# Altium schematic sheet MCU_&_Sensors.SchDoc
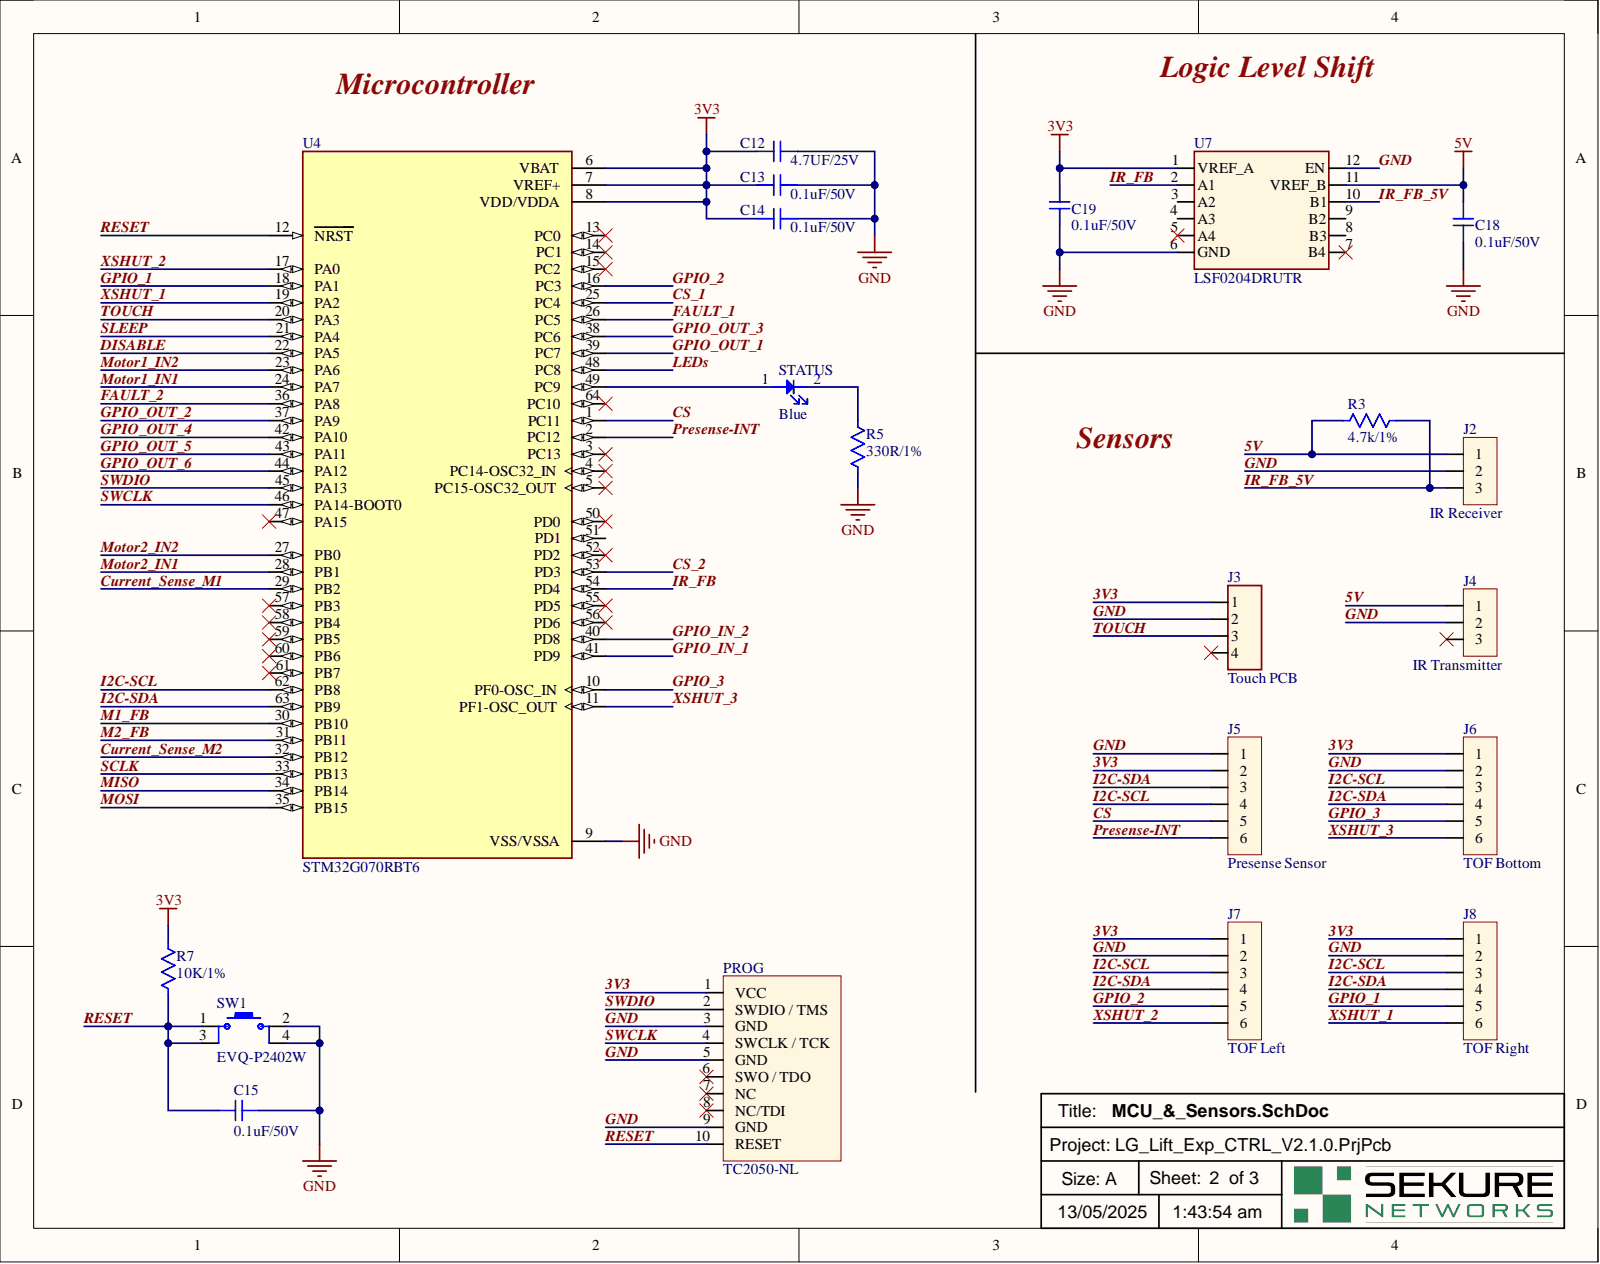
[diagram p0: MCU_&_Sensors.SchDoc]

## Parts
C12  value 4.7UF/25V  [CAP 0603]  (hidden comment: Capacitor)
C13  value 0.1uF/50V  [CAP 0603]  (hidden comment: Ceramic Capacitor)
C14  value 0.1uF/50V  [CAP 0603]  (hidden comment: Ceramic Capacitor)
C15  value 0.1uF/50V  [CAP 0603]  (hidden comment: Ceramic Capacitor)
C18  value 0.1uF/50V  [CAP 0603]  (hidden comment: Ceramic Capacitor)
C19  value 0.1uF/50V  [CAP 0603]  (hidden comment: Ceramic Capacitor)
J2  value IR Receiver  [S3B-PH_JST]  (hidden comment: Connector)
J3  value Touch PCB  [SM04B-PASS-TBT]  (hidden comment: Connector)
J4  value IR Transmitter  [S3B-PH_JST]  (hidden comment: Connector)
J5  value Presense Sensor  [S6B-PH_JST]  (hidden comment: Connector)
J6  value TOF Bottom  [S6B-PH_JST]  (hidden comment: Connector)
J7  value TOF Left  [S6B-PH_JST]  (hidden comment: Connector)
J8  value TOF Right  [S6B-PH_JST]  (hidden comment: Connector)
PROG  value TC2050-NL  [TC2050_full]  (hidden comment: Programming Connector)
R3  value 4.7k/1%  [RES 0603]  (hidden comment: Resistor)
R5  value 330R/1%  [RES 0603]  (hidden comment: Resistor)
R7  value 10K/1%  [RES 0603]  (hidden comment: Resistor)
STATUS  value Blue  Color: Blue  [APT1608SURCK]  (hidden comment: LED)
SW1  value EVQ-P2402W  [EVQ-P2402W]  (hidden comment: Switch)
U4  Microcontroller  value STM32G070RBT6  [64-LQFP]
U7  value LSF0204DRUTR  [LSF0204RUTR]  (hidden comment: IC)

## Nets
3V3: C12.2 C13.2 C14.2 C19.1 J3.1 J5.2 J6.1 J7.1 J8.1 PROG.1(VCC) R7.1 U4.6(VBAT) U4.7(VREF+) U4.8(VDD/VDDA) U7.1(VREF_A)
5V: C18.1 J2.1 J4.1 R3.2 U7.11(VREF_B)
CS: J5.5 U4.1(PC11)
CS_1: U4.25(PC4)
CS_2: U4.53(PD3)
Current_Sense_M1: U4.29(PB2)
Current_Sense_M2: U4.32(PB12)
DISABLE: U4.22(PA5)
FAULT_1: U4.26(PC5)
FAULT_2: U4.36(PA8)
GND: C12.1 C13.1 C14.1 C15.1 C18.2 C19.2 J2.2 J3.2 J4.2 J5.1 J6.2 J7.2 J8.2 PROG.3(GND) PROG.5(GND) PROG.9(GND) R5.1 SW1.2 SW1.4 U4.9(VSS/VSSA) U7.6(GND) U7.12(EN)
GPIO_1: J8.5 U4.18(PA1)
GPIO_2: J7.5 U4.16(PC3)
GPIO_3: J6.5 U4.10(PF0-OSC_IN)
GPIO_IN_1: U4.41(PD9)
GPIO_IN_2: U4.40(PD8)
GPIO_OUT_1: U4.39(PC7)
GPIO_OUT_2: U4.37(PA9)
GPIO_OUT_3: U4.38(PC6)
GPIO_OUT_4: U4.42(PA10)
GPIO_OUT_5: U4.43(PA11)
GPIO_OUT_6: U4.44(PA12)
I2C-SCL: J5.4 J6.3 J7.3 J8.3 U4.62(PB8)
I2C-SDA: J5.3 J6.4 J7.4 J8.4 U4.63(PB9)
IR_FB: U4.54(PD4) U7.2(A1)
IR_FB_5V: J2.3 R3.1 U7.10(B1)
LEDs: U4.48(PC8)
M1_FB: U4.30(PB10)
M2_FB: U4.31(PB11)
MISO: U4.34(PB14)
MOSI: U4.35(PB15)
Motor1_IN1: U4.24(PA7)
Motor1_IN2: U4.23(PA6)
Motor2_IN1: U4.28(PB1)
Motor2_IN2: U4.27(PB0)
Presense-INT: J5.6 U4.2(PC12)
RESET: C15.2 PROG.10(RESET) R7.2 SW1.1 SW1.3 U4.12(NRST)
SCLK: U4.33(PB13)
SLEEP: U4.21(PA4)
SWCLK: PROG.4(SWCLK_/_TCK) U4.46(PA14-BOOT0)
SWDIO: PROG.2(SWDIO_/_TMS) U4.45(PA13)
TOUCH: J3.3 U4.20(PA3)
XSHUT_1: J8.6 U4.19(PA2)
XSHUT_2: J7.6 U4.17(PA0)
XSHUT_3: J6.6 U4.11(PF1-OSC_OUT)
NetR5_2: R5.2 STATUS.2
NetSTATUS_1: STATUS.1 U4.49(PC9)

Not connected: J3 4; J4 3; PROG 6(SWO_/_TDO) 7(NC) 8(NC/TDI); U4 3(PC13) 4(PC14-OSC32_IN) 5(PC15-OSC32_OUT) 13(PC0) 14(PC1) 15(PC2) 47(PA15) 50(PD0) 51(PD1) 52(PD2) 55(PD5) 56(PD6) 57(PB3) 58(PB4) 59(PB5) 60(PB6) 61(PB7) 64(PC10); U7 3(A2) 4(A3) 5(A4) 7(B4) 8(B3) 9(B2)

## Library data of the parts
C12 = GRM188R61E475KE11D: CAP CER 4.7UF 25V X5R 0603; Manufacturer: Murata; Material: Ceramic; Tolerance: 10%; Capacitance: 4.7uF; Case Code (Imperial): 0603; Case Code (Metric): 1608; Case/Package: 0603; Component Kind: Standard; ComponentLink1Description: https://datasheet.ciiva.com/46444/1769893-46444982.pdf?src-supplier=Element14; ComponentLink1URL: https://datasheet.ciiva.com/46444/1769893-46444982.pdf?src-supplier=Element14; ComponentLink2Description: https://datasheet.ciiva.com/46444/1769893-46444983.pdf?src-supplier=Element14; ComponentLink2URL: https://datasheet.ciiva.com/46444/1769893-46444983.pdf?src-supplier=Element14; ComponentLink3Description: https://datasheet.ciiva.com/14891/partnumbering-e-01-14891102.pdf?src-supplier=Digi-Key; ComponentLink3URL: https://datasheet.ciiva.com/14891/partnumbering-e-01-14891102.pdf?src-supplier=Digi-Key; ComponentLink4Description: https://datasheet.ciiva.com/14891/partnumbering-e-01-14891103.pdf?src-supplier=Digi-Key; ComponentLink4URL: https://datasheet.ciiva.com/14891/partnumbering-e-01-14891103.pdf?src-supplier=Digi-Key; Depth: 0.8mm; Dielectric: X5R; DigiKey: 490-7203-1-ND; Height: 0.8mm; Lead Free: Lead Free; Length: 1.6mm; Library Name: Ceramic Capacitors.IntLib; Max Operating Temperature: 85°C; Min Operating Temperature: -55°C; Mount: Surface Mount; P/N: GRM188R61E475KE11D; Package Quantity: 4000; Packaging: Cut Tape; Pricing 1: 1=0.41000, 10=0.28400, 100=0.17040, 500=0.12780, 1000=0.10793 (USD); REACH SVHC: No SVHC; RoHS: Yes; Stock 1: 593246; Supplier 1: Digi-Key; Thickness: 0.031inch; Voltage: 25V; Voltage Rating: 25V; Voltage Rating (DC): 25V; Width: 0.031inch
C13-C15 C18 C19 = C0603G104K5RAC7867: CAP CER 0.1UF 50V X7R 0603; Manufacturer: KEMET; Capacitance: 100nF; Tolerance: 10%; Case Code (Imperial): 0603; Case Code (Metric): 1608; Case/Package: 0603; Component Kind: Standard; ComponentLink1Description: http://www.kemet.com/Lists/ProductCatalog/Attachments/44/KEM_CC106_HITEMP.pdf?src-supplier=Digi-Key; ComponentLink1URL: http://www.kemet.com/Lists/ProductCatalog/Attachments/44/KEM_CC106_HITEMP.pdf?src-supplier=Digi-Key; DigiKey: 399-11919-1-ND; Features: High Temperature; Length: 0.063inch; Library Name: Ceramic Capacitors.IntLib; Max Operating Temperature: 175°C; Min Operating Temperature: -55°C; Mount: Surface Mount; P/N: C0603G104K5RAC7867; Packaging: Cut Tape; Pricing 1: 1=2.02000, 10=1.63200, 50=1.42440, 100=1.24640, 500=0.94962, 1000=0.83092 (USD); RoHS: Yes; Stock 1: 15997; Supplier 1: Digi-Key; Thickness: 0.034inch; Voltage Rating: 50V; Width: 0.031inch
J2 J4 = S3B-PH-SM4-TB: Header S3B-PH-SM4-TB, 2.00mm, 3-contacts, side entry, SMT, /1000/reel; Manufacturer: JST; Component Kind: Standard; DigiKey: 455-S3B-PH-SM4-TBCT-ND; Library Name: Connectors.IntLib; Orientation: Horizontal; P/N: S3B-PH-SM4-TB; Pricing: 1=0.68, 10=0.591, 100=0.4869, 500=0.42336 (USD); RoHS: No; Stock: 8368; Supplier: Digikey
J3 = SM04B-PASS-TBT: CONN HEADER SMD R/A 4POS 2MM; Manufacturer: JST; Category: LDO; Component Kind: Standard; DigiKey: 455-2645-1-ND; Library Name: Connectors.IntLib; P/N: SM04B-PASS-TBT; Pricing: 1=1.14, 10=0.966, 100=0.8674, 500=0.70972 (USD); RoHS: No; Stock: 6960; Supplier: Digikey
J5-J8 = S6B-PH-SM4-TB: Header S6B-PH-SM4-TB, 2.00mm, 6-contacts, side entry, SMT, /1000/reel; Manufacturer: JST; Component Kind: Standard; DigiKey: 455-S6B-PH-SM4-TBCT-ND; Library Name: Connectors.IntLib; P/N: S6B-PH-SM4-TB; Pricing: 1=0.89, 10=0.748, 100=0.6716, 500=0.54948 (USD); RoHS: No; Stock: 10902; Supplier: Digikey
PROG = TC2050_Full: “No Legs” 10-pin Plug-of-Nails™ Cable fitted with a 10-pin 0.1″ pitch ribbon connector.; Assembly: DNP; Component Kind: Standard; Library Name: Programming Connectors.IntLib
R3 = ERJ-3EKF4701V: RES SMD, 4.7K 0603, 0.1W, 1%; Manufacturer: Panasonic; Max Power Dissipation: 100mW; Resistance: 4.7kR; Temperature Coefficient: 100ppm/°C; Voltage Rating: 75V; Case Code (Imperial): 0603; Case Code (Metric): 1608; Case/Package: 0603; Component Kind: Standard; ComponentLink1Description: https://datasheet.ciiva.com/16449/aoa0000ce2-16449479.pdf?src-supplier=Future+Electronics; ComponentLink1URL: https://datasheet.ciiva.com/16449/aoa0000ce2-16449479.pdf?src-supplier=Future+Electronics; ComponentLink2Description: https://datasheet.ciiva.com/16511/aoa0000ce2-16511783.pdf?src-supplier=Avnet+Asia; ComponentLink2URL: https://datasheet.ciiva.com/16511/aoa0000ce2-16511783.pdf?src-supplier=Avnet+Asia; ComponentLink3Description: https://datasheet.ciiva.com/9195/aoa0000ce2-9195740.pdf?src-supplier=Avnet; ComponentLink3URL: https://datasheet.ciiva.com/9195/aoa0000ce2-9195740.pdf?src-supplier=Avnet; ComponentLink4Description: https://datasheet.ciiva.com/16758/70260748-16758189.pdf?src-supplier=Allied+Electronics; ComponentLink4URL: https://datasheet.ciiva.com/16758/70260748-16758189.pdf?src-supplier=Allied+Electronics; ComponentLink5Description: https://datasheet.ciiva.com/24160/aoa0000ce2-24160445.pdf?src-supplier=Mouser; ComponentLink5URL: https://datasheet.ciiva.com/24160/aoa0000ce2-24160445.pdf?src-supplier=Mouser; ComponentLink6Description: https://datasheet.ciiva.com/46372/1678782-46372717.pdf?src-supplier=Element14; ComponentLink6URL: https://datasheet.ciiva.com/46372/1678782-46372717.pdf?src-supplier=Element14; ComponentLink7Description: https://datasheet.ciiva.com/17213/aoa0000ce2-17213182.pdf?src-supplier=Avnet+Europe; ComponentLink7URL: https://datasheet.ciiva.com/17213/aoa0000ce2-17213182.pdf?src-supplier=Avnet+Europe; ComponentLink8Description: https://datasheet.ciiva.com/7830/426256-7830985.pdf?src-supplier=Element14; ComponentLink8URL: https://datasheet.ciiva.com/7830/426256-7830985.pdf?src-supplier=Element14; ComponentLink9Description: https://datasheet.ciiva.com/46372/1678782-46372716.pdf?src-supplier=Element14; ComponentLink9URL: https://datasheet.ciiva.com/46372/1678782-46372716.pdf?src-supplier=Element14; ComponentLink10Description: https://datasheet.ciiva.com/7830/aoa0000ce2-7830735.pdf?src-supplier=Element14; ComponentLink10URL: https://datasheet.ciiva.com/7830/aoa0000ce2-7830735.pdf?src-supplier=Element14; Composition: Thick Film; Contact Plating: Tin; Depth: 0.8mm; DigiKey: P4.70KHDKR-ND; Features: Automotive AEC-Q200; Height: 0.022inch; Lead Free: Lead Free; Length: 1.6mm; Library Name: Resistors.IntLib; Max Operating Temperature: 155°C; Military Standard: Not; Min Operating Temperature: -55°C; Mount: Surface Mount; Number of Pins: 2; Number of Terminations: 2; P/N: ERJ-3EKF4701V; Package Quantity: 1; Packaging: Tape and Reel; Power Rating: 100mW; Pricing 1: 1=0.10000, 10=0.05700, 100=0.02340, 1000=0.01049, 2500=0.00911 (USD); Radiation Hardening: No; REACH SVHC: No SVHC; RoHS: Yes; Stock 1: 588466; Supplier 1: Digi-Key; Termination: SMD/SMT; Tolerance: 1%; Voltage Rating (DC): 75V; Weight: 7.1E-05oz; Width: 0.031inch
R5 = ERJ3EKF3300V: RES SMD 330 OHM 1% 1/10W 0603; Manufacturer: Panasonic; Max Power Dissipation: 100mW; Resistance: 330R; Temperature Coefficient: 100ppm/°C; Voltage Rating: 75V; Case Code (Imperial): 0603; Case Code (Metric): 1608; Case/Package: 0603; Component Kind: Standard; ComponentLink1Description: https://datasheet.ciiva.com/48531/aoa0000ce2-48531001.pdf?src-supplier=Avnet; ComponentLink1URL: https://datasheet.ciiva.com/48531/aoa0000ce2-48531001.pdf?src-supplier=Avnet; ComponentLink2Description: https://datasheet.ciiva.com/22721/426256-22721099.pdf?src-supplier=Element14; ComponentLink2URL: https://datasheet.ciiva.com/22721/426256-22721099.pdf?src-supplier=Element14; ComponentLink3Description: https://datasheet.ciiva.com/46373/1678782-46373899.pdf?src-supplier=Element14; ComponentLink3URL: https://datasheet.ciiva.com/46373/1678782-46373899.pdf?src-supplier=Element14; ComponentLink4Description: https://datasheet.ciiva.com/7886/aoa0000ce2-7886729.pdf?src-supplier=Element14; ComponentLink4URL: https://datasheet.ciiva.com/7886/aoa0000ce2-7886729.pdf?src-supplier=Element14; ComponentLink5Description: https://datasheet.ciiva.com/9117/aoa0000ce2-9117132.pdf?src-supplier=Avnet; ComponentLink5URL: https://datasheet.ciiva.com/9117/aoa0000ce2-9117132.pdf?src-supplier=Avnet; ComponentLink6Description: https://datasheet.ciiva.com/46373/1678782-46373900.pdf?src-supplier=Element14; ComponentLink6URL: https://datasheet.ciiva.com/46373/1678782-46373900.pdf?src-supplier=Element14; Composition: Thick Film; Contact Plating: Tin; Depth: 0.8mm; DigiKey: P330HCT-ND; Features: Automotive AEC-Q200; Height: 0.45mm; Lead Free: Lead Free; Length: 1.6mm; Library Name: Resistors.IntLib; Max Operating Temperature: 155°C; Military Standard: Not; Min Operating Temperature: -55°C; Mount: Surface Mount; Number of Pins: 2; Number of Terminations: 2; P/N: ERJ3EKF3300V; Package Quantity: 5000; Packaging: Tape and Reel; Power Rating: 100mW; Pricing 1: 1=0.10000, 10=0.07000, 100=0.02840, 1000=0.01275, 2500=0.01106 (USD); Radiation Hardening: No; REACH SVHC: No SVHC; RoHS: Yes; Stock 1: 1950276; Supplier 1: Digi-Key; Termination: SMD/SMT; Tolerance: 1%; Voltage Rating (DC): 75V; Weight: 7.1E-05oz; Width: 0.8mm
R7 = RC0603FR-0710KL: RES SMD 10K OHM 1% 1/10W 0603; Manufacturer: Yageo; Power Rating: 100mW; Resistance: 10kR; Temperature Coefficient: 100ppm/°C; Voltage Rating: 75V; Voltage Rating (DC): 50V; Case Code (Imperial): 0603; Case Code (Metric): 1608; Case/Package: 0603; Component Kind: Standard; ComponentLink1Description: https://datasheet.ciiva.com/7660/38590-7660789.pdf?src-supplier=Element14; ComponentLink1URL: https://datasheet.ciiva.com/7660/38590-7660789.pdf?src-supplier=Element14; ComponentLink2Description: https://datasheet.ciiva.com/15189/getdatasheetpartid-409289-15189591.pdf?src-supplier=Verical; ComponentLink2URL: https://datasheet.ciiva.com/15189/getdatasheetpartid-409289-15189591.pdf?src-supplier=Verical; ComponentLink3Description: https://datasheet.ciiva.com/46440/1868787-46440891.pdf?src-supplier=Element14; ComponentLink3URL: https://datasheet.ciiva.com/46440/1868787-46440891.pdf?src-supplier=Element14; ComponentLink4Description: https://datasheet.ciiva.com/7983/443971-7983596.pdf?src-supplier=Element14; ComponentLink4URL: https://datasheet.ciiva.com/7983/443971-7983596.pdf?src-supplier=Element14; ComponentLink5Description: https://datasheet.ciiva.com/7746/1738695-7746239.pdf?src-supplier=Element14; ComponentLink5URL: https://datasheet.ciiva.com/7746/1738695-7746239.pdf?src-supplier=Element14; ComponentLink6Description: https://datasheet.ciiva.com/22747/443971-22747519.pdf?src-supplier=Element14; ComponentLink6URL: https://datasheet.ciiva.com/22747/443971-22747519.pdf?src-supplier=Element14; Composition: Thick Film; Depth: 0.8mm; DigiKey: 311-10.0KHRDKR-ND; Height: 0.45mm; Lead Free: Lead Free; Lead Pitch: 0.3mm; Length: 1.6mm; Library Name: Resistors.IntLib; Max Operating Temperature: 155°C; Military Standard: Not; Min Operating Temperature: -55°C; Number of Pins: 2; P/N: RC0603FR-0710KL; Packaging: Tape and Reel; Pricing 1: 1=0.10000, 10=0.02300, 100=0.00940, 1000=0.00421, 2500=0.00366 (USD); REACH SVHC: No SVHC; RoHS: Yes; Stock 1: 55833360; Supplier 1: Digi-Key; Termination: SMD/SMT; Tolerance: 1%; Weight: 7.1E-05oz; Width: 0.8mm
STATUS = APT1608QBC/D: Single Color LED, Blue, Water Clear, 1.2mm; Manufacturer: Kingbright; Channels: 1; Forward Current: 30mA; Luminous Intensity-Nom: 100mcd; Overall Height: 0.75mm; Shape: Rectangular; Viewing Angle: 120°; Category: LEDs; Component Kind: Standard; ComponentLink1Description: https://datasheet.ciiva.com:443/pdfs/VipMasterIC/IC/KNGB/KNGBS06436/KNGBS06436-1.pdf?src-supplier=IHS+Markit; ComponentLink1URL: https://datasheet.ciiva.com:443/pdfs/VipMasterIC/IC/KNGB/KNGBS06436/KNGBS06436-1.pdf?src-supplier=IHS+Markit; ComponentLink2Description: https://datasheet.ciiva.com/12403/apt1608qbc-d-65281-12403861.pdf?src-supplier=Mouser; ComponentLink2URL: https://datasheet.ciiva.com/12403/apt1608qbc-d-65281-12403861.pdf?src-supplier=Mouser; ComponentLink3Description: https://datasheet.ciiva.com/2121/apt1608qbc-d-2121092.pdf?src-supplier=Digikey; ComponentLink3URL: https://datasheet.ciiva.com/2121/apt1608qbc-d-2121092.pdf?src-supplier=Digikey; ComponentLink4Description: https://datasheet.ciiva.com/2121/apt1608qbc-d-2121093.pdf?src-supplier=Digikey; ComponentLink4URL: https://datasheet.ciiva.com/2121/apt1608qbc-d-2121093.pdf?src-supplier=Digikey; ComponentLink5Description: https://datasheet.ciiva.com/2121/apt1608qbc-d-2121094.pdf?src-supplier=Digikey; ComponentLink5URL: https://datasheet.ciiva.com/2121/apt1608qbc-d-2121094.pdf?src-supplier=Digikey; DigiKey: 754-1434-1-ND; Lens Style: WATER CLEAR; Library Name: LEDs.IntLib; Max Operating Temperature: 85°C; Min Operating Temperature: -40°C; Mounting Technology: Surface Mount; Optoelectronic Device Type: SINGLE COLOR LED; P/N: APT1608QBC/D; Packaging: Tape and Reel; Pins: 2; Pricing: 1=0.43, 10=0.263, 100=0.151, 1000=0.11817 (USD); RoHS: Yes; RoHS Compliant: Yes; Size: 1.2mm; Stock: 112434; Supplier: Digikey; Terminal Pitch: 1.65mm
SW1 = EVQ-P2402W: Keypad Switch, 1 Switches, SPST, Momentary, 0.02A, 15VDC, 2.4N, Solder Terminal, Surface Mount-straight; Manufacturer: Panasonic; Manufacturer Series: EVQP2; Body Breadth: 3.5inch; Body Height: 1.65mm; Body Length or Diameter: 4.7mm; Circuit Configuration: 1X1; Contact Bounce Time-Max: 10ms; Contact Current(DC)-Max: 20mA; Contact DC Max Rating R Load: .02A@15VDC; Contact Voltage(DC)-Max: 15V; Electrical Life: 500000Cycles; Number of Switches: 1; Category: Tactile Switches; Component Kind: Standard; ComponentLink1Description: https://datasheet.ciiva.com:443/pdfs/VipMasterIC/IC/PANA/PANAS38695/PANAS38695-1.pdf?src-supplier=IHS+Markit; ComponentLink1URL: https://datasheet.ciiva.com:443/pdfs/VipMasterIC/IC/PANA/PANAS38695/PANAS38695-1.pdf?src-supplier=IHS+Markit; ComponentLink2Description: https://datasheet.ciiva.com/2901/atk0000ce6-2901462.pdf?src-supplier=Digikey; ComponentLink2URL: https://datasheet.ciiva.com/2901/atk0000ce6-2901462.pdf?src-supplier=Digikey; ComponentLink3Description: https://datasheet.ciiva.com/2901/atk0000ce6-2901463.pdf?src-supplier=Digikey; ComponentLink3URL: https://datasheet.ciiva.com/2901/atk0000ce6-2901463.pdf?src-supplier=Digikey; ComponentLink4Description: https://datasheet.ciiva.com/2901/atk0000ce6-2901464.pdf?src-supplier=Digikey; ComponentLink4URL: https://datasheet.ciiva.com/2901/atk0000ce6-2901464.pdf?src-supplier=Digikey; ComponentLink5Description: https://datasheet.ciiva.com/8166/atv0000ce7-8166415.pdf?src-supplier=Avnet; ComponentLink5URL: https://datasheet.ciiva.com/8166/atv0000ce7-8166415.pdf?src-supplier=Avnet; ComponentLink6Description: https://datasheet.ciiva.com/30238/atv0000ce7-30238297.pdf?src-supplier=Onlinecomponents.com; ComponentLink6URL: https://datasheet.ciiva.com/30238/atv0000ce7-30238297.pdf?src-supplier=Onlinecomponents.com; DigiKey: P11081SCT-ND; JESD-609 Code: e4; Library Name: Buttons.IntLib; Max Operating Temperature: 85°C; Min Operating Temperature: -40°C; Mounting Technology: Surface Mount; Operating Force-Max: 2.4N; P/N: EVQ-P2402W; Packaging: Tape and Reel; Pricing: 1=0.71, 10=0.68, 25=0.6536, 100=0.5674, 250=0.51772, 500=0.48112, 1000=0.43144 (USD); Reach SVHC Compliant: Yes; RoHS: Yes; RoHS Compliant: Yes; Stock: 16627; Supplier: Digikey; Switch Action: MOMENTARY; Switch Function: SPST; Switch Type: Keypad; Terminal Finish: Gold; Termination: Solder
U4 = STM32G070RBT6: RISC Microcontroller, 32-Bit, FLASH, CORTEX-M0 CPU, 64MHz, CMOS, PQFP64; Manufacturer: STMicroelectronics; Category: Microcontrollers; Component Kind: Standard; ComponentLink1Description: https://datasheet.ciiva.com:443/pdfs/VipMasterIC/IC/SGST/SGST-S-A0007407296/SGST-S-A0007407296-1.pdf?src-supplier=IHS+Markit; ComponentLink1URL: https://datasheet.ciiva.com:443/pdfs/VipMasterIC/IC/SGST/SGST-S-A0007407296/SGST-S-A0007407296-1.pdf?src-supplier=IHS+Markit; ComponentLink2Description: https://datasheet.octopart.com/STM32G070RBT6-STMicroelectronics-datasheet-130125764.pdf?src-supplier=LKR; ComponentLink2URL: https://datasheet.octopart.com/STM32G070RBT6-STMicroelectronics-datasheet-130125764.pdf?src-supplier=LKR; DigiKey: 497-STM32G070RBT6-ND; Library Name: Microcontrollers.IntLib; P/N: STM32G070RBT6; Pricing: 1=2.98, 10=2.674, 25=2.5224, 80=2.14913, 230=2.018, 440=1.76573, 960=1.46303, 2880=1.36214, 5760=1.31169 (USD); RoHS: No; RoHS Compliant: Yes; Stock: 2374; Supplier: Digikey
U7 = LSF0204DRUTR: 4-Bit Bidirectional Multi-Voltage Level Translator for Open-Drain & Push-Pull 12-UQFN -40 to 125; Manufacturer: Texas Instruments; Max Supply Voltage: 5V; Min Supply Voltage: 0uV; Number of Bits: 4; Number of Channels: 1; Operating Supply Current: 3.5uA; Propagation Delay: 5.29ns; Category: Voltage Level Shifters; Channel Type: Bidirectional; Component Kind: Standard; ComponentLink1Description: http://www.ti.com/lit/ds/symlink/lsf0204d.pdf?src-supplier=Digi-Key; ComponentLink1URL: http://www.ti.com/lit/ds/symlink/lsf0204d.pdf?src-supplier=Digi-Key; ComponentLink2Description: http://www.ti.com/lit/gpn/lsf0204d?src-supplier=Mouser; ComponentLink2URL: http://www.ti.com/lit/gpn/lsf0204d?src-supplier=Mouser; ComponentLink3Description: http://www.ti.com/general/docs/lit/getliterature.tsp?genericPartNumber=LSF0204D&&fileType=pdf&src-supplier=Avnet; ComponentLink3URL: http://www.ti.com/general/docs/lit/getliterature.tsp?genericPartNumber=LSF0204D&&fileType=pdf&src-supplier=Avnet; DigiKey: 296-40402-1-ND; Lead Free: Lead Free; Library Name: IC's.IntLib; Logic Function: Translator; Max Operating Temperature: 125°C; Min Operating Temperature: -40°C; Mount: Surface Mount; Number of Circuits: 1; Number of Pins: 12; P/N: LSF0204DRUTR; Package Quantity: 3000; Packaging: Tape and Reel; Pricing 1: 1=1.13000, 10=1.01000, 25=0.95880, 100=0.78750, 250=0.73612, 500=0.65052, 1000=0.51680 (USD); Quiescent Current: 3.5uA; RoHS: Yes; RoHS Compliant: Yes; Stock 1: 20275; Supplier 1: Digi-Key
